# Altium schematic sheet PowerDistribution.SchDoc
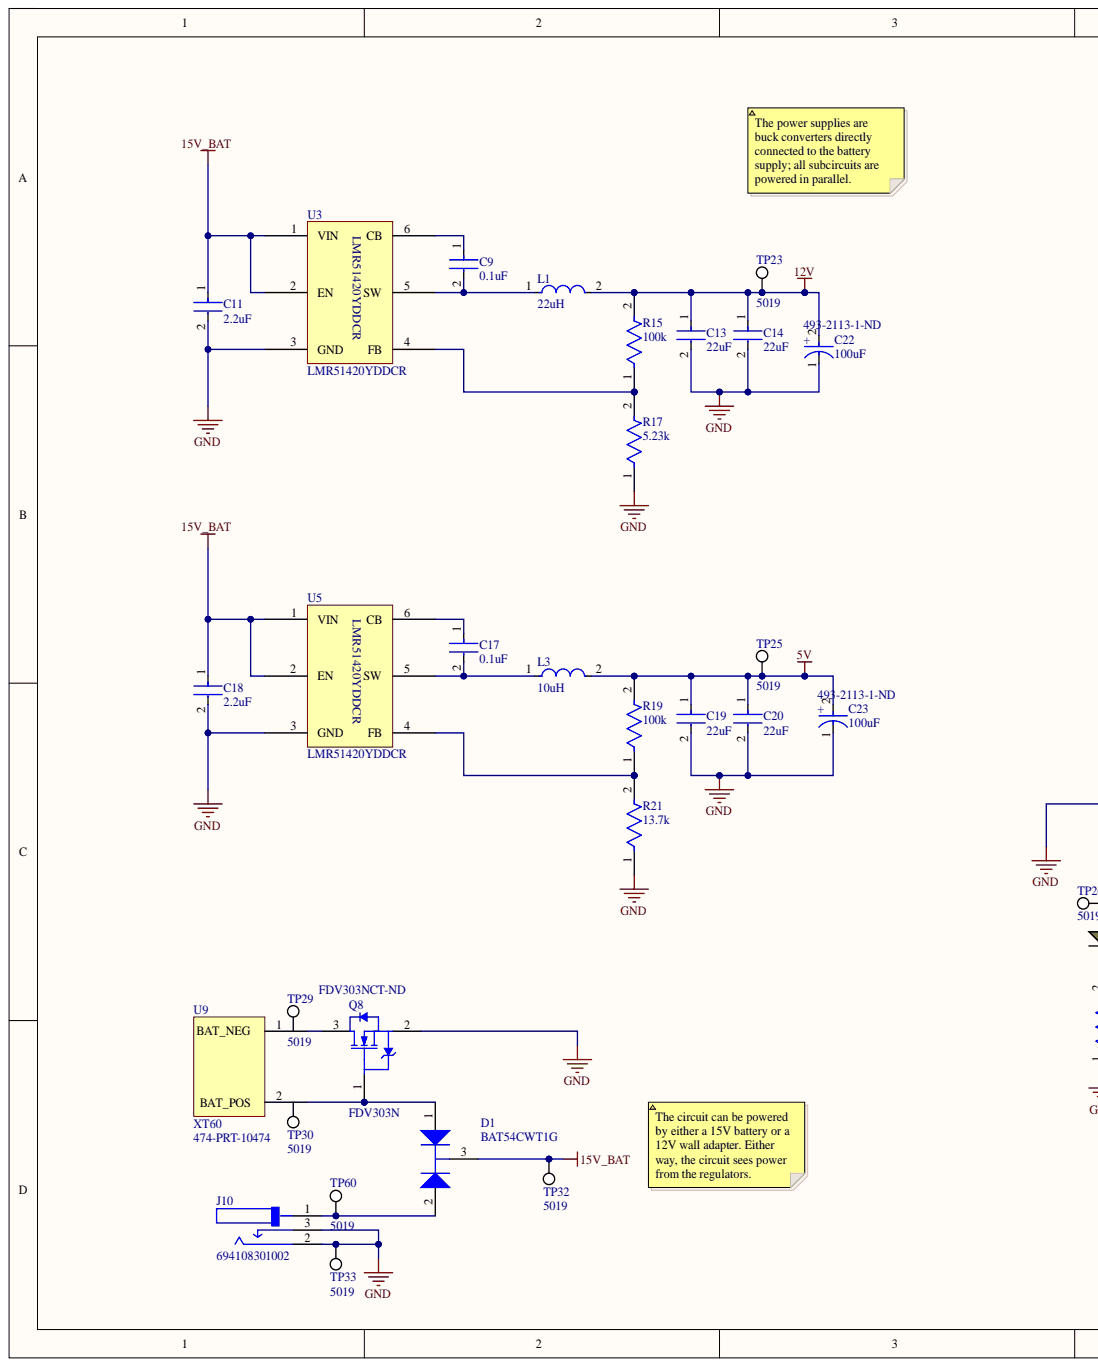
[diagram p0: PowerDistribution.SchDoc, left]
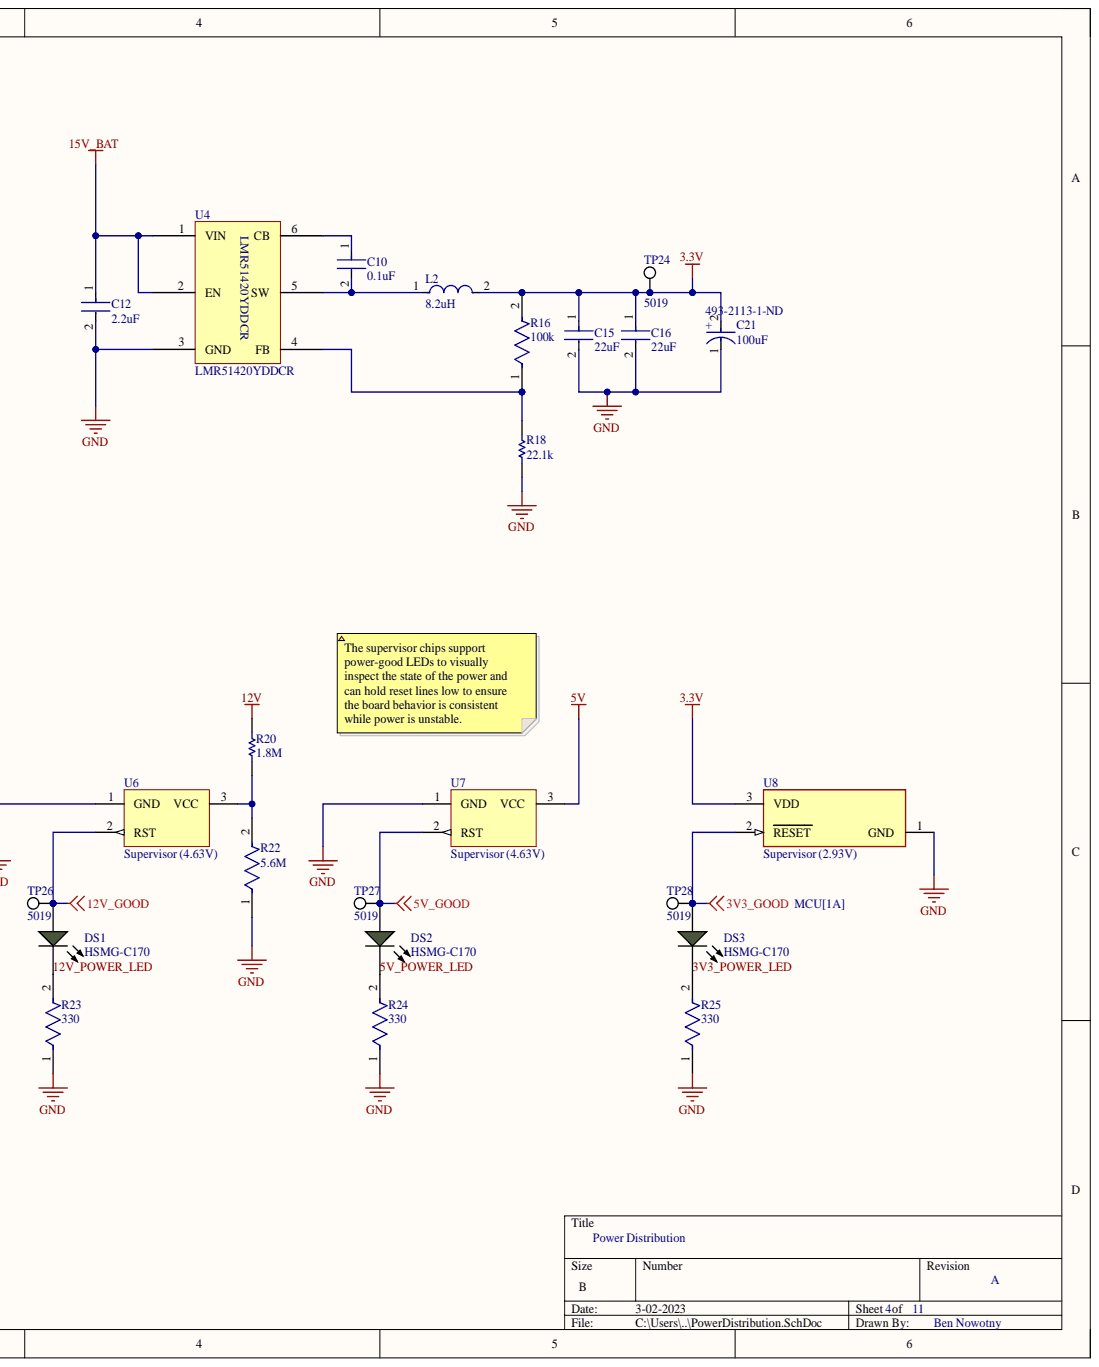
[diagram p1: PowerDistribution.SchDoc, right]

## Parts
C9  0.1uF  [FP-CC0805-0_85-MFG]
C10  0.1uF  [FP-CC0805-0_85-MFG]
C11  2.2uF  [FP-CGA4J-IPC_A]
C12  2.2uF  [FP-CGA4J-IPC_A]
C13  22uF  [FP-GRM21B-0_2-e0_2_0_7-IPC_B]
C14  22uF  [FP-GRM21B-0_2-e0_2_0_7-IPC_B]
C15  22uF  [FP-GRM21B-0_2-e0_2_0_7-IPC_B]
C16  22uF  [FP-GRM21B-0_2-e0_2_0_7-IPC_B]
C17  0.1uF  [FP-CC0805-0_85-MFG]
C18  2.2uF  [FP-CGA4J-IPC_A]
C19  22uF  [FP-GRM21B-0_2-e0_2_0_7-IPC_B]
C20  22uF  [FP-GRM21B-0_2-e0_2_0_7-IPC_B]
C21  100uF  Supplier Part Number 1: 493-2113-1-ND  [FP-UWX1E101MCL1GB-MFG]
C22  100uF  Supplier Part Number 1: 493-2113-1-ND  [FP-UWX1E101MCL1GB-MFG]
C23  100uF  Supplier Part Number 1: 493-2113-1-ND  [FP-UWX1E101MCL1GB-MFG]
D1  BAT54CWT1G  [ONSC-SOT-323-3-419-04_V]
DS1  HSMG-C170  [AVAG-HSMX-C170_V]
DS2  HSMG-C170  [AVAG-HSMX-C170_V]
DS3  HSMG-C170  [AVAG-HSMX-C170_V]
J10  694108301002  [FP-694108301002-MFG]
L1  22uH  [FP-LB2012-1_25-0_2-IPC_C]
L2  8.2uH  [FP-SRP4020TA-MFG]
L3  10uH  [FP-LB2012-1_25-0_2-MFG]
Q8  FDV303N  Supplier Part Number 1: FDV303NCT-ND  [FAIR-FDV303N-3_V]
R15  100k  [FP-RC0805-0_6-IPC_C]
R16  100k  [FP-RC0805-0_6-IPC_C]
R17  5.23k  [FP-CRCW0805-e3-IPC_B]
R18  22.1k  [RESC2013X70X40NL20T20]
R19  100k  [FP-RC0805-0_6-IPC_C]
R20  1.8M  [RESC0805(2012)_N]
R21  13.7k  [FP-CRCW0805-e3-IPC_A]
R22  5.6M  [FP-KTR10-IPC_C]
R23  330  [FP-CRCW0805-e3-MFG]
R24  330  [FP-CRCW0805-e3-MFG]
R25  330  [FP-CRCW0805-e3-MFG]
TP23  5019  [KSTN-5019_V]
TP24  5019  [KSTN-5019_V]
TP25  5019  [KSTN-5019_V]
TP26  5019  [KSTN-5019_V]
TP27  5019  [KSTN-5019_V]
TP28  5019  [KSTN-5019_V]
TP29  5019  [KSTN-5019_V]
TP30  5019  [KSTN-5019_V]
TP32  5019  [KSTN-5019_V]
TP33  5019  [KSTN-5019_V]
TP60  5019  [KSTN-5019_V]
U3  LMR51420YDDCR  [BUCK_REG_FOOTPRINT_SOT95P280X110-6N]
U4  LMR51420YDDCR  [BUCK_REG_FOOTPRINT_SOT95P280X110-6N]
U5  LMR51420YDDCR  [BUCK_REG_FOOTPRINT_SOT95P280X110-6N]
U6  Supervisor (4.63V)  [DBZ0003A_M]
U7  Supervisor (4.63V)  [DBZ0003A_M]
U8  Supervisor (2.93V)  [FP-DBV0003A-MFG]
U9  XT60  Supplier Part Number 1: 474-PRT-10474  [PCBComponent_1]

## Nets
3.3V: C15.1 C16.1 C21.2 L2.2 R16.2 TP24.1 U8.3
3V3_GOOD: DS3.1 TP28.1 U8.2
5V: C19.1 C20.1 C23.2 L3.2 R19.2 TP25.1 U7.3
5V_GOOD: DS2.1 TP27.1 U7.2
12V: C13.1 C14.1 C22.2 L1.2 R15.2 R20.2 TP23.1
12V_GOOD: DS1.1 TP26.1 U6.2
15V_BAT: C11.1 C12.1 C18.1 D1.3 TP32.1 U3.1(VIN) U3.2(EN) U4.1(VIN) U4.2(EN) U5.1(VIN) U5.2(EN)
GND: C11.2 C12.2 C13.2 C14.2 C15.2 C16.2 C18.2 C19.2 C20.2 C21.1 C22.1 C23.1 J10.2 J10.3 Q8.2 R17.1 R18.1 R21.1 R22.1 R23.1 R24.1 R25.1 TP33.1 U3.3(GND) U4.3(GND) U5.3(GND) U6.1 U7.1 U8.1
3V3_POWER_LED: DS3.2 R25.2
5V_POWER_LED: DS2.2 R24.2
12V_POWER_LED: DS1.2 R23.2
NetC9_1: C9.1 U3.6(CB)
NetC9_2: C9.2 L1.1 U3.5(SW)
NetC10_1: C10.1 U4.6(CB)
NetC10_2: C10.2 L2.1 U4.5(SW)
NetC17_1: C17.1 U5.6(CB)
NetC17_2: C17.2 L3.1 U5.5(SW)
NetD1_1: D1.1 Q8.1 U9.2
NetD1_2: D1.2 J10.1 TP60.1
NetQ8_3: Q8.3 U9.1
NetR15_1: R15.1 R17.2 U3.4(FB)
NetR16_1: R16.1 R18.2 U4.4(FB)
NetR19_1: R19.1 R21.2 U5.4(FB)
NetR20_1: R20.1 R22.2 U6.3

Not connected: TP29 1; TP30 1
